# Altium schematic sheet OTL2_LED-Driver.SchDoc(OTL2_LED-Driver)
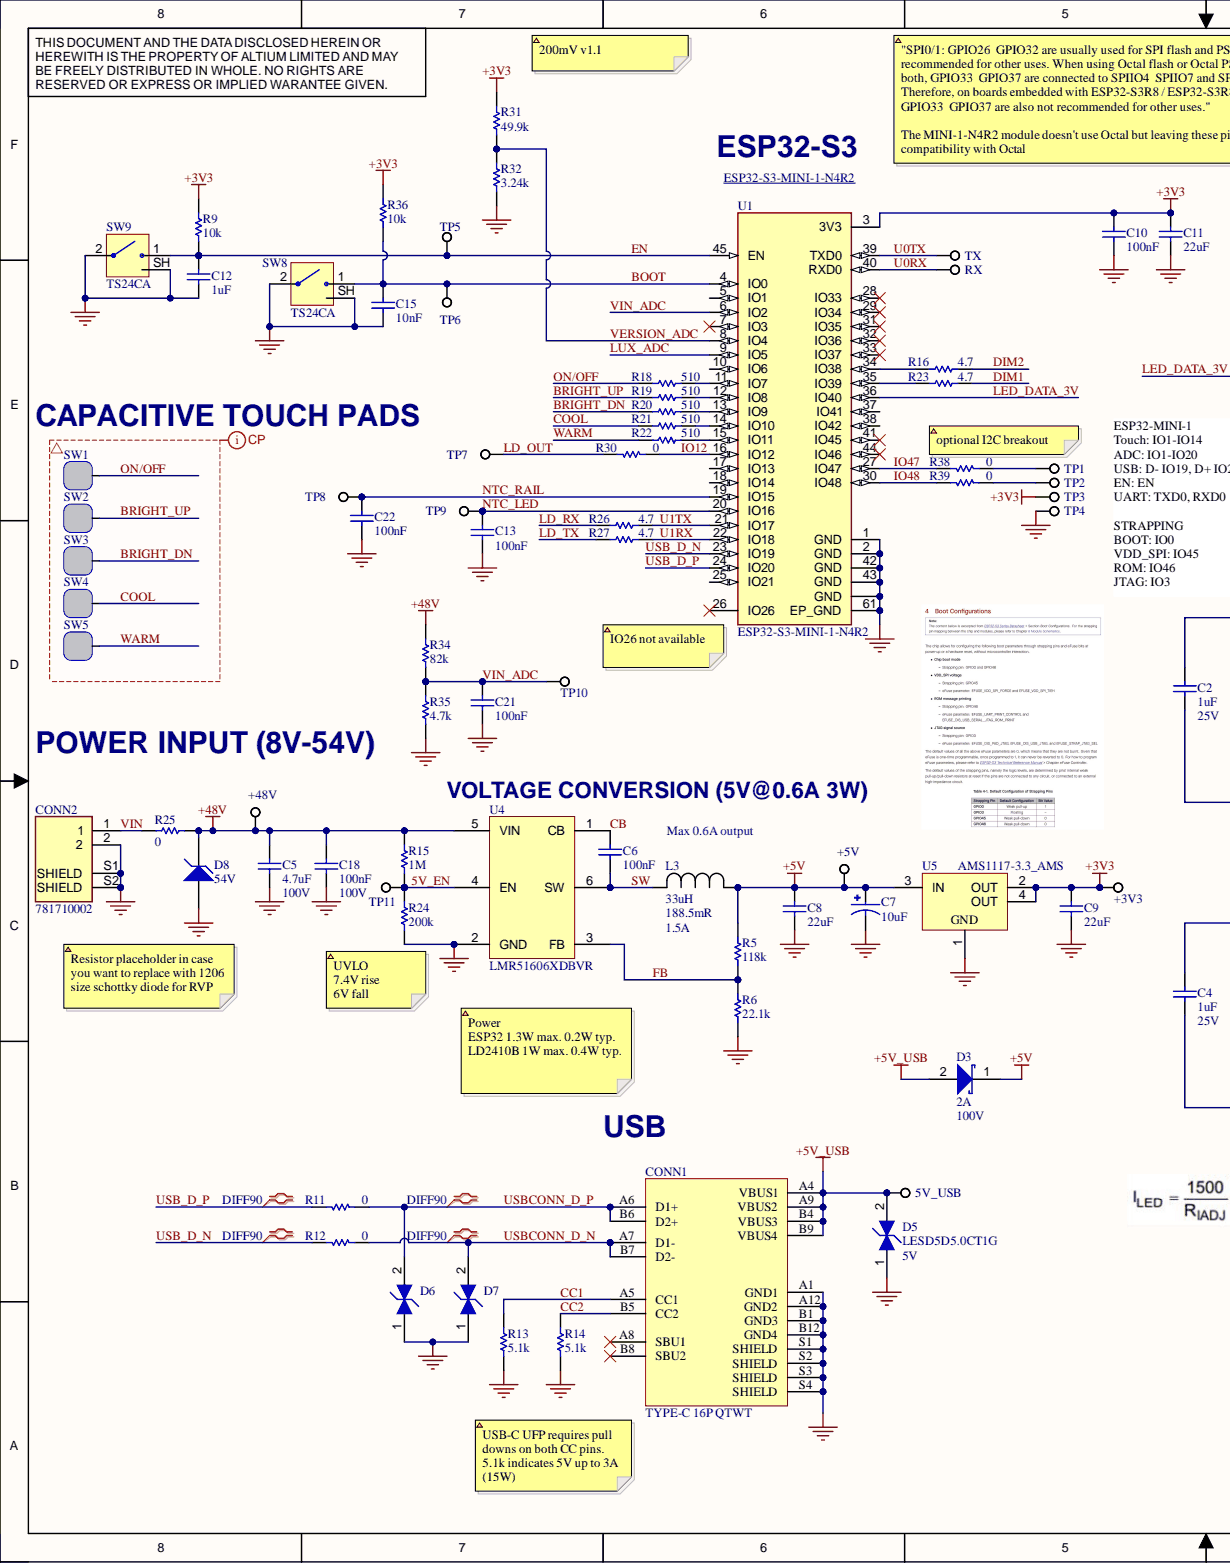
[diagram p0: OTL2_LED-Driver.SchDoc, left]
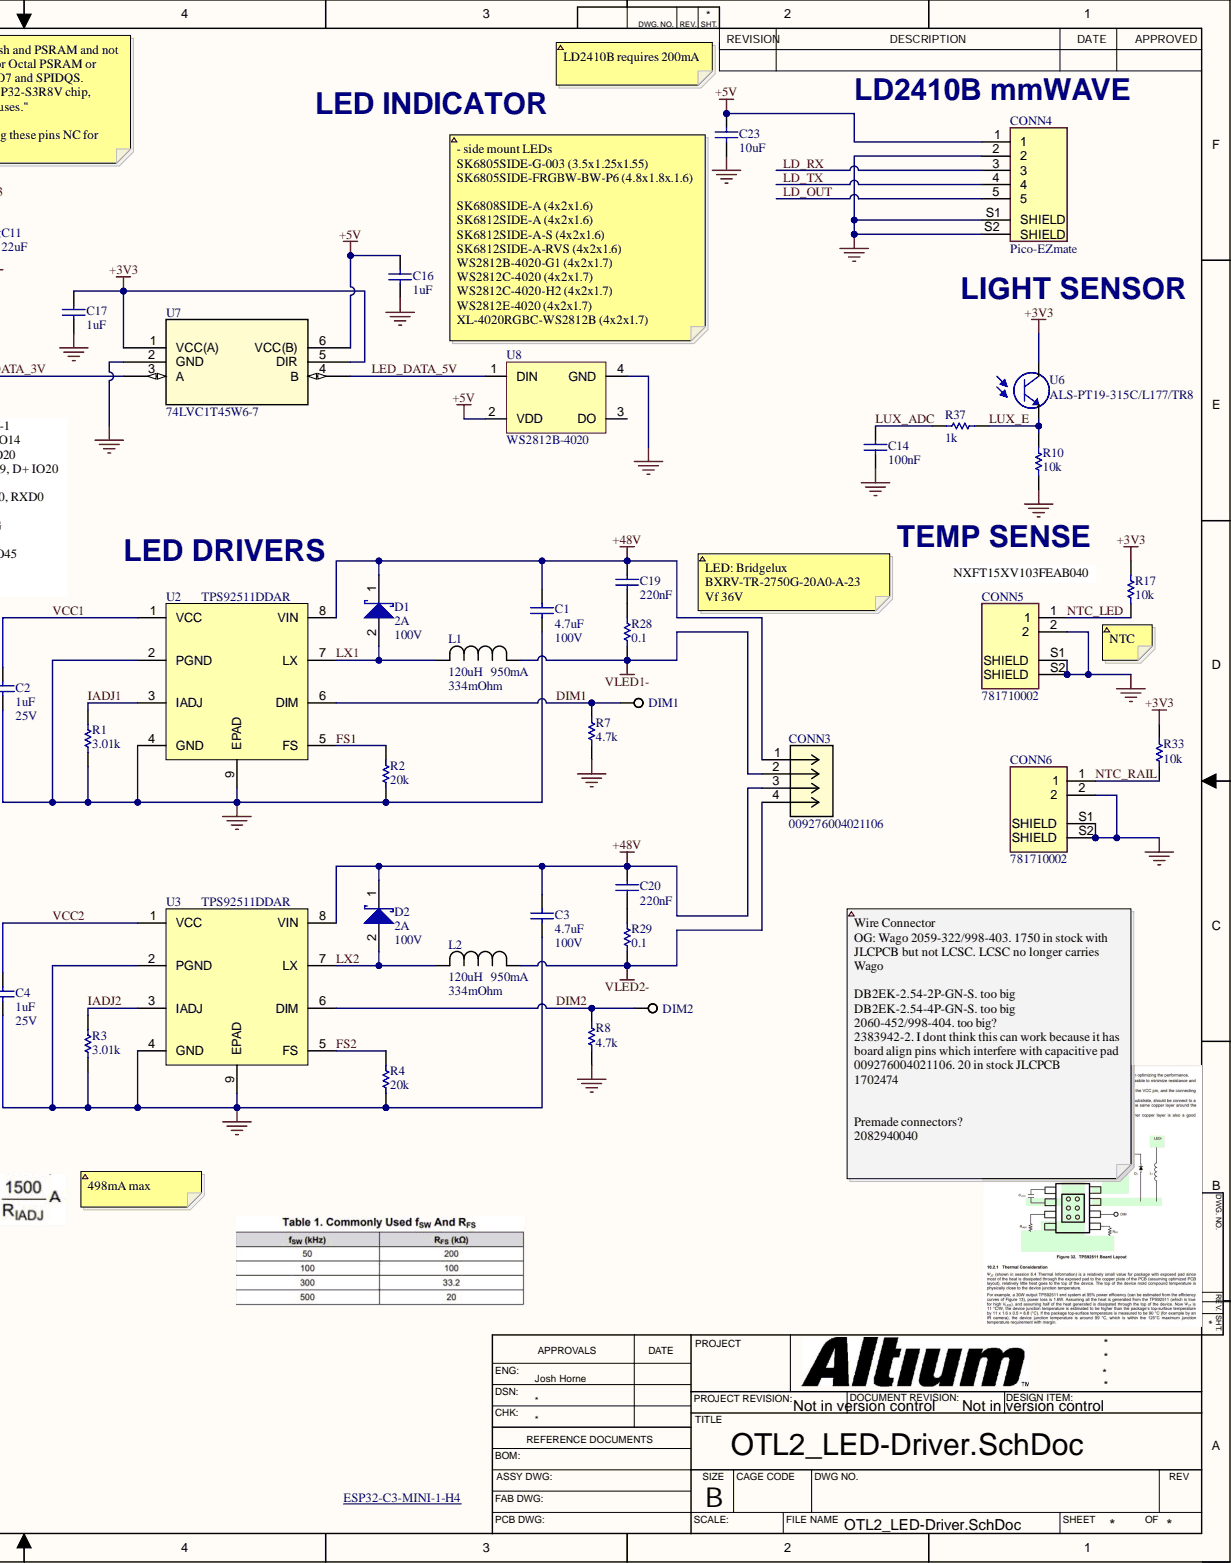
[diagram p1: OTL2_LED-Driver.SchDoc, right]

## Parts
5V_USB  TP  [Test_Point]  (value not drawn)  (no BOM)
+3V3  TP  [Test_Point]  (value not drawn)  (no BOM)
+5V  TP  [Test_Point]  (value not drawn)  (no BOM)
+48V  TP  [Test_Point]  (value not drawn)  (no BOM)
C1  4.7uF  Voltage Rating: 100V  [CAP_1206_SK]
C2  1uF  Voltage Rating: 25V  [CAP_0402_SK]
C3  4.7uF  Voltage Rating: 100V  [CAP_1206_SK]
C4  1uF  Voltage Rating: 25V  [CAP_0402_SK]
C5  4.7uF  Voltage Rating: 100V  [CAP_1206_SK]
C6  100nF  [CAP_0402_SK]
C7  10uF  [CAPMP3216X180N]
C8  22uF  [CAP_0805_SK]
C9  22uF  [CAP_0805_SK]
C10  100nF  [CAP_0402_SK]
C11  22uF  [CAP_0805_SK]
C12  1uF  [CAP_0402_SK]
C13  100nF  [CAP_0402_SK]
C14  100nF  [CAP_0402_SK]
C15  10nF  [CAP_0402_SK]
C16  1uF  [CAP_0402_SK]
C17  1uF  [CAP_0402_SK]
C18  100nF  Voltage Rating: 100V  [CAP_0805_SK]
C19  220nF  [CAP_0805_SK]
C20  220nF  [CAP_0805_SK]
C21  100nF  [CAP_0402_SK]
C22  100nF  [CAP_0402_SK]
C23  10uF  [CAP_0603_SK]
CONN1  TYPE-C 16P QTWT  [CONN_USBC_C5187472]
CONN2  781710002  [MOLEX_0781710002]
CONN3  009276004021106  [CONN_009276004021006_AVX]
CONN4  Pico-EZmate  [CONN_781710000-SD_05_MOL]
CONN5  781710002  [MOLEX_0781710002_HANDSOLDER_NTC]
CONN6  781710002  [MOLEX_0781710002_HANDSOLDER_NTC]
D1  Average Rectified Current: 2A  Max Repetitive Reverse Voltage (Vrrm): 100V  [DIOD_DO-220AA (SMP)]  (hidden comment: SS2PH10-M3/84A)
D2  Average Rectified Current: 2A  Max Repetitive Reverse Voltage (Vrrm): 100V  [DIOD_DO-220AA (SMP)]  (hidden comment: SS2PH10-M3/84A)
D3  Average Rectified Current: 2A  Max Repetitive Reverse Voltage (Vrrm): 100V  [DIOD_DO-220AA (SMP)]  (hidden comment: SS2PH10-M3/84A)
D5  Manufacturer Part Number: LESD5D5.0CT1G  Vrwm: 5V  [DIOD_SOD523]  (hidden comment: LESD5D5.0CT1G_Leiditech)
D6  LESD5D5.0CT1G_Leiditech  [DIOD_SOD523]  (value not drawn)
D7  LESD5D5.0CT1G_Leiditech  [DIOD_SOD523]  (value not drawn)
D8  54V  [DIOD-SMA-2_V]
DIM1  TP  [Test_Point_0.8mm]  (value not drawn)  (no BOM)
DIM2  TP  [Test_Point_0.8mm]  (value not drawn)  (no BOM)
L1  120uH  Current Rating: 950mA  DCR: 334mOhm  [IND_8x8x4]
L2  120uH  Current Rating: 950mA  DCR: 334mOhm  [IND_8x8x4]
L3  33uH  DC Resistance (DCR): 188.5mR  Max DC Current: 1.5A  [NR6045]
R1  3.01k  [RES_0402]
R2  20k  [RES_0402]
R3  3.01k  [RES_0402]
R4  20k  [RES_0402]
R5  118k  [RES_0402]
R6  22.1k  [RES_0402]
R7  4.7k  [RES_0402]
R8  4.7k  [RES_0402]
R9  10k  [RES_0402]
R10  10k  [RES_0402]
R11  0  [RES_0402]
R12  0  [RES_0402]
R13  5.1k  [RES_0402]
R14  5.1k  [RES_0402]
R15  1M  [RES_0402]
R16  4.7  [RES_0402]
R17  10k  [RES_0402]
R18  510  [RES_0402]
R19  510  [RES_0402]
R20  510  [RES_0402]
R21  510  [RES_0402]
R22  510  [RES_0402]
R23  4.7  [RES_0402]
R24  200k  [RES_0402]
R25  0  [RES_1206]
R26  4.7  [RES_0402]
R27  4.7  [RES_0402]
R28  0.1  [RES_0603]
R29  0.1  [RES_0603]
R30  0  [RES_0402]
R31  49.9k  [RES_0402]
R32  3.24k  [RES_0402]
R33  10k  [RES_0402]
R34  82k  [RES_0603]
R35  4.7k  [RES_0402]
R36  10k  [RES_0402]
R37  1k  [RES_0402]
R38  0  [RES_0402]
R39  0  [RES_0402]
RX  TP  [Test_Point]  (value not drawn)  (no BOM)
SW1  Capacitive Touch Pad  [CAP_PAD_15_circle]  (value not drawn)  (no BOM)
SW2  Capacitive Touch Pad  [CAP_PAD_10mm]  (value not drawn)  (no BOM)
SW3  Capacitive Touch Pad  [CAP_PAD_10mm]  (value not drawn)  (no BOM)
SW4  Capacitive Touch Pad  [CAP_PAD_10mm]  (value not drawn)  (no BOM)
SW5  Capacitive Touch Pad  [CAP_PAD_10mm]  (value not drawn)  (no BOM)
SW8  TS24CA  [SW_TS24CA]
SW9  TS24CA  [SW_TS24CA]
TP1  TP  [Test_Point]  (no BOM)
TP2  TP  [Test_Point]  (no BOM)
TP3  TP  [Test_Point]  (no BOM)
TP4  TP  [Test_Point]  (no BOM)
TP5  TP  [Test_Point_0.8mm]  (no BOM)
TP6  TP  [Test_Point_0.8mm]  (no BOM)
TP7  TP  [Test_Point_0.8mm]  (no BOM)
TP8  TP  [Test_Point_0.8mm]  (no BOM)
TP9  TP  [Test_Point_0.8mm]  (no BOM)
TP10  TP  [Test_Point_0.8mm]  (no BOM)
TP11  TP  [Test_Point_0.8mm]  (no BOM)
TX  TP  [Test_Point]  (value not drawn)  (no BOM)
U1  ESP32-S3-MINI-1-N4R2  [ESP32-S3-MINI-1-N4R2_noVias]
U2  TPS92511DDAR  [VREG_LM5017MR/NOPB]
U3  TPS92511DDAR  [VREG_LM5017MR/NOPB]
U4  LMR51606XDBVR  [SOT-23-6]
U5  AMS1117-3.3_AMS  [DCY0004A_L]
U6  ALS-PT19-315C/L177/TR8  [XDCR_ALS-PT19-315C/L177/TR8]
U7  74LVC1T45W6-7  [SOT-23-6]
U8  WS2812B-4020  [WS2812B-4020]

## Nets
+3V3: +3V3.1 C9.2 C10.2 C11.2 C17.2 R9.2 R17.2 R31.2 R33.2 R36.2 TP3.1 U1.3(3V3) U5.2(OUT) U5.4(OUT) U6.1 U7.1(VCC(A)) U7.5(DIR)
+48V: +48V.1 C1.1 C3.1 C5.2 C18.2 C19.2 C20.2 CONN3.1 CONN3.3 D1.1 D2.1 D8.2 R15.2 R25.2 R34.2 U2.8(VIN) U3.8(VIN) U4.5(VIN)
GND: C1.2 C2.1 C3.2 C4.1 C5.1 C7.2 C8.1 C9.1 C10.1 C11.1 C12.1 C13.1 C14.1 C15.1 C16.1 C17.1 C18.1 C21.1 C22.1 C23.1 CONN1.A1(GND1) CONN1.A12(GND2) CONN1.B1(GND3) CONN1.B12(GND4) CONN1.S1(SHIELD) CONN1.S2(SHIELD) CONN1.S3(SHIELD) CONN1.S4(SHIELD) CONN2.2 CONN2.S1(SHIELD) CONN2.S2(SHIELD) CONN4.2 CONN4.S1(SHIELD) CONN4.S2(SHIELD) CONN5.2 CONN5.S1(SHIELD) CONN5.S2(SHIELD) CONN6.2 CONN6.S1(SHIELD) CONN6.S2(SHIELD) D5.1 D6.1 D7.1 D8.1 R1.1 R2.1 R3.1 R4.1 R6.1 R7.1 R8.1 R10.1 R13.1 R14.1 R24.1 R32.1 R35.1 SW8.2 SW8.SH SW9.2 SW9.SH TP4.1 U1.1(GND) U1.2(GND) U1.42(GND) U1.43(GND) U1.46, 47, 48, 49, 50, 51, 52, 53, 54, 55, 56, 57, 58, 59, 60, 62, 63, 64, 65(GND) U1.61(EP_GND) U2.2(PGND) U2.4(GND) U2.9(EPAD) U3.2(PGND) U3.4(GND) U3.9(EPAD) U4.2(GND) U5.1 U7.2(GND) U8.4((3.2x2.8))
VLED1-: CONN3.2 L1.2 R28.1
VLED2-: CONN3.4 L2.2 R29.1
5V_EN: 5V_USB.1 +5V.1 C7.1 C8.2 C16.2 C23.2 CONN1.A4(VBUS1) CONN1.A9(VBUS2) CONN1.B4(VBUS3) CONN1.B9(VBUS4) CONN4.1 D3.1 D3.2 D5.2 L3.1 R5.1 R15.1 R24.2 TP11.1 U4.4(EN) U5.3(IN) U7.6(VCC(B)) U8.2(VDD)
BOOT: C15.2 R36.1 SW8.1 TP6.1 U1.4(IO0)
BRIGHT_DN: R20.1 SW3.1
BRIGHT_UP: R19.1 SW2.1
CB: C6.2 U4.1(CB)
CC1: CONN1.A5(CC1) R13.2
CC2: CONN1.B5(CC2) R14.2
COOL: R21.1 SW4.1
DIM1: DIM1.1 R7.2 R23.2 U2.6(DIM)
DIM2: DIM2.1 R8.2 R16.2 U3.6(DIM)
EN: C12.2 R9.1 SW9.1 TP5.1 U1.45(EN)
FB: R5.2 R6.2 U4.3(FB)
FS1: R2.2 U2.5(FS)
FS2: R4.2 U3.5(FS)
IADJ1: R1.2 U2.3(IADJ)
IADJ2: R3.2 U3.3(IADJ)
IO12: R30.1 U1.16(IO12)
IO47: R38.2 U1.27(IO47)
IO48: R39.2 U1.30(IO48)
LD_OUT: CONN4.5 R30.2 TP7.1
LD_RX: CONN4.3 R26.1
LD_TX: CONN4.4 R27.1
LED_DATA_3V: U1.36(IO40) U7.3(A)
LED_DATA_5V: U7.4(B) U8.1(DIN)
LUX_ADC: C14.2 R37.1 U1.9(IO5)
LUX_E: R10.2 R37.2 U6.2
LX1: D1.2 L1.1 U2.7(LX)
LX2: D2.2 L2.1 U3.7(LX)
NTC_LED: C13.2 CONN5.1 R17.1 TP9.1 U1.20(IO16)
NTC_RAIL: C22.2 CONN6.1 R33.1 TP8.1 U1.19(IO15)
ON/OFF: R18.1 SW1.1
SW: C6.1 L3.2 U4.6(SW)
U0RX: RX.1 U1.40(RXD0)
U0TX: TX.1 U1.39(TXD0)
U1RX: R27.2 U1.22(IO18)
U1TX: R26.2 U1.21(IO17)
USB_D_N: R12.2 U1.23(IO19)
USB_D_P: R11.2 U1.24(IO20)
USBCONN_D_N: CONN1.A7(D1-) CONN1.B7(D2-) D7.2 R12.1
USBCONN_D_P: CONN1.A6(D1+) CONN1.B6(D2+) D6.2 R11.1
VCC1: C2.2 U2.1(VCC)
VCC2: C4.2 U3.1(VCC)
VERSION_ADC: R31.1 R32.2 U1.8(IO4)
VIN: CONN2.1 R25.1
VIN_ADC: C21.2 R34.1 R35.2 TP10.1 U1.6(IO2)
WARM: R22.1 SW5.1
NetC19_1: C19.1 R28.2
NetC20_1: C20.1 R29.2
NetR16_1: R16.1 U1.34(IO38)
NetR18_2: R18.2 U1.11(IO7)
NetR19_2: R19.2 U1.12(IO8)
NetR20_2: R20.2 U1.13(IO9)
NetR21_2: R21.2 U1.14(IO10)
NetR22_2: R22.2 U1.15(IO11)
NetR23_1: R23.1 U1.35(IO39)
NetR38_1: R38.1 TP1.1
NetR39_1: R39.1 TP2.1

Not connected: CONN1 A8(SBU1) B8(SBU2); U1 5(IO1) 7(IO3) 10(IO6) 17(IO13) 18(IO14) 25(IO21) 26(IO26) 28(IO33) 29(IO34) 31(IO35) 32(IO36) 33(IO37) 37(IO41) 38(IO42) 41(IO45) 44(IO46); U8 3(DO)
